# Altium schematic sheet DMP_SEM_POWER.SchDoc(DMP_SEM_POWER)
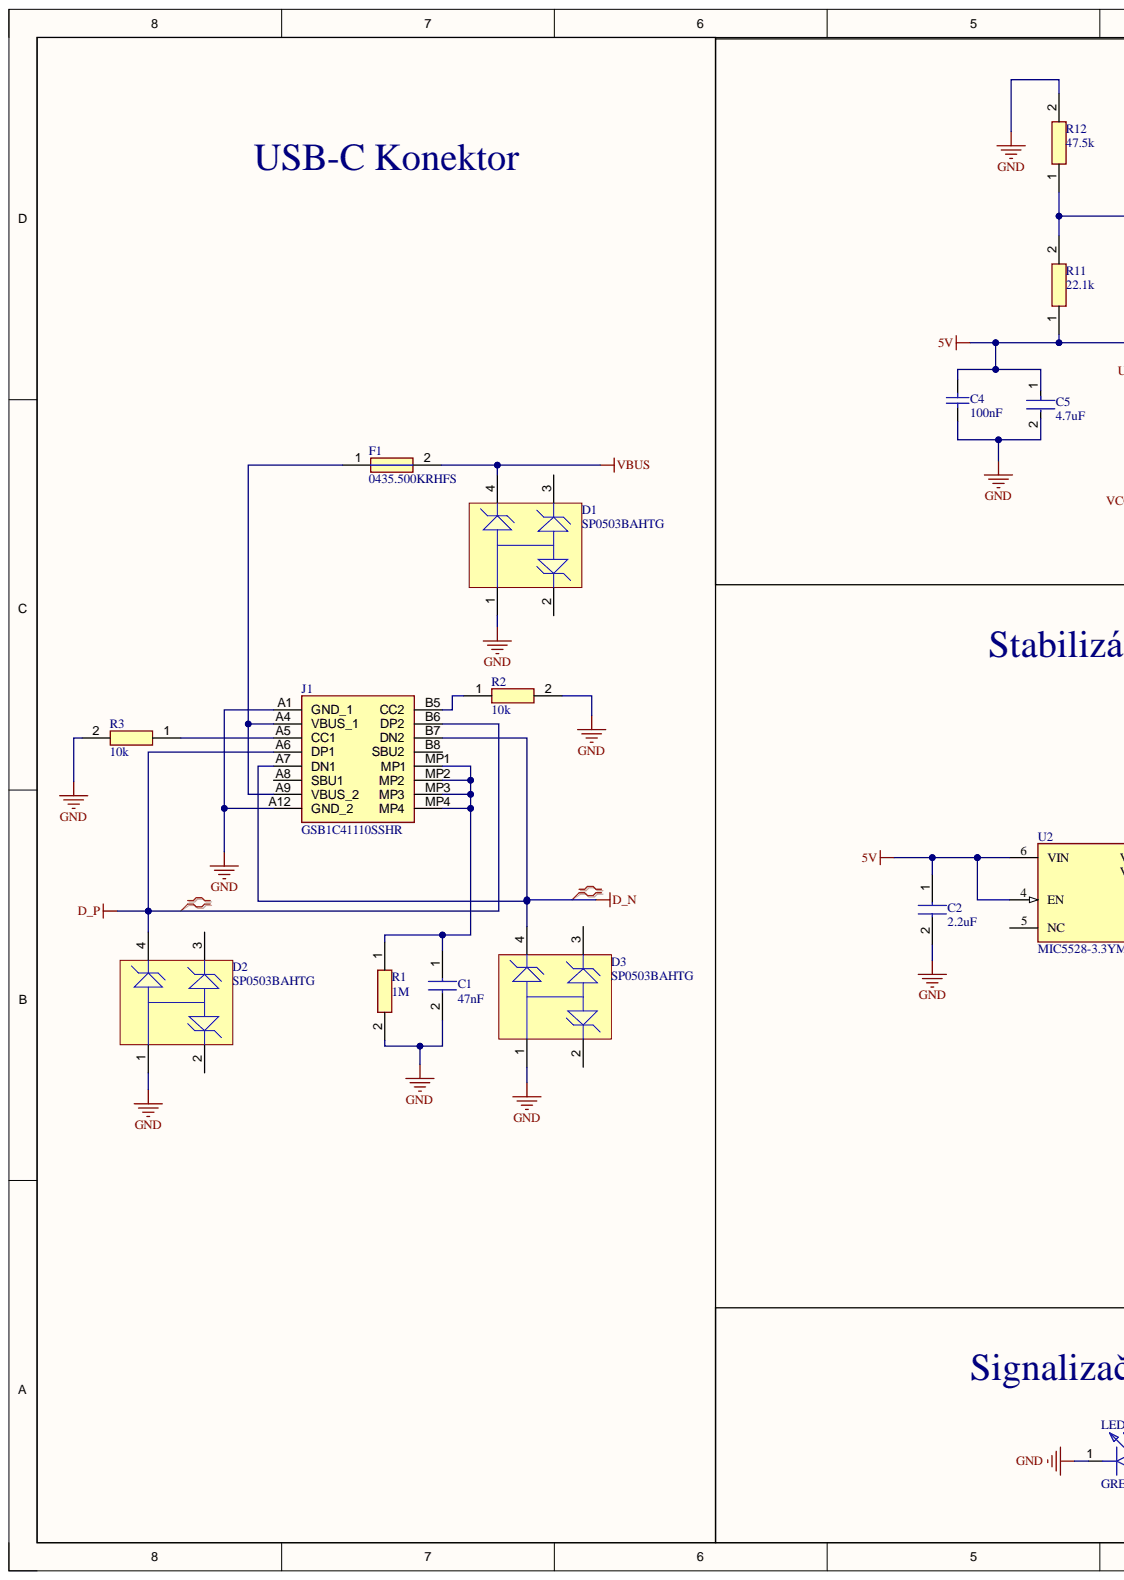
[diagram p0: DMP_SEM_POWER.SchDoc, left]
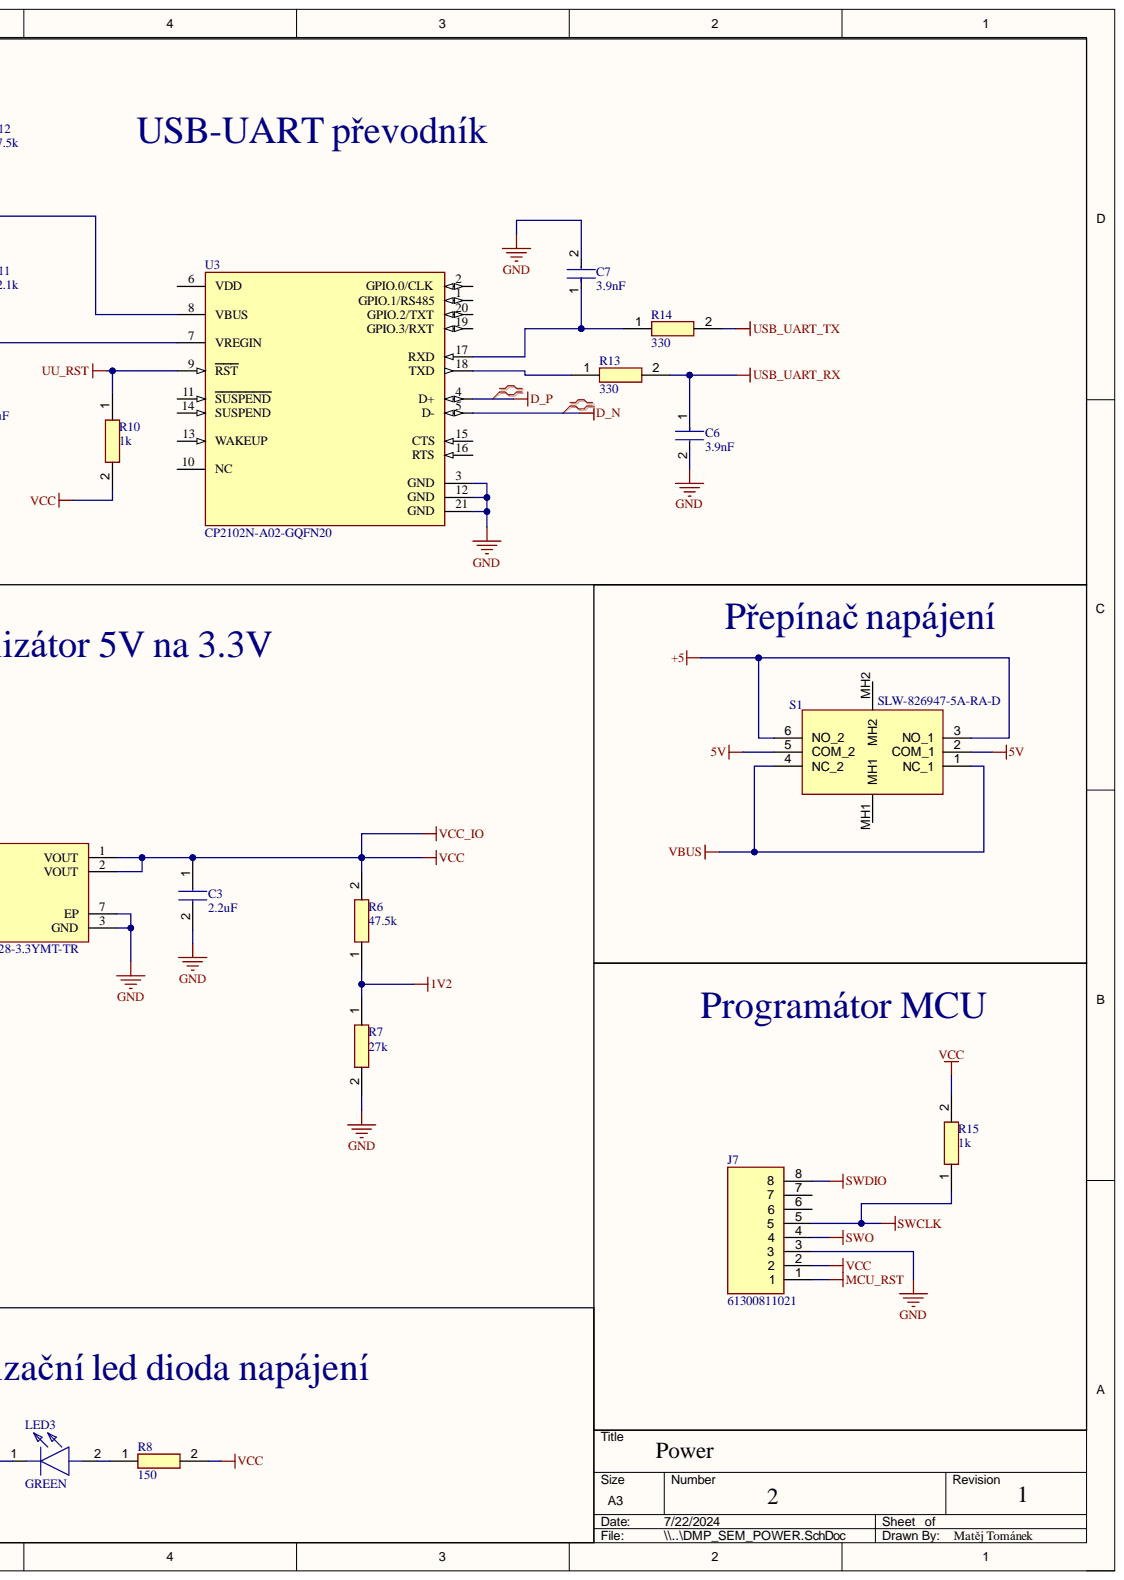
[diagram p1: DMP_SEM_POWER.SchDoc, right]

## Parts
C1  47nF  [C0603]
C2  2.2uF  [CAPC1608X90N]
C3  2.2uF  [CAPC1608X90N]
C4  100nF  [CAPC1050X55X23ML05T13]
C5  4.7uF  [FP-CL828-IPC_A]
C6  3.9nF  [FP-CL22-IPC_B]
C7  3.9nF  [FP-CL22-IPC_B]
D1  SP0503BAHTG  [LFUS-SOT143-4_V]
D2  SP0503BAHTG  [LFUS-SOT143-4_V]
D3  SP0503BAHTG  [LFUS-SOT143-4_V]
F1  0435.500KRHFS  [FUSC1005X43N]
J1  GSB1C41110SSHR  [GSB1C41110SSHR]
J7  61300811021  [HDRRA8W64P0X254_1X8_2032X254X254P]
LED3  GREEN  [LEDC1608X40N]
R1  1M  [RESC1005X40N]
R2  10k  [RESC1608X50N]
R3  10k  [RESC1608X50N]
R6  47.5k  [ERJP03_PA3_(0603)]
R7  27k  [ERA6AEB1020V]
R8  150  [RESC1005X40N]
R10  1k  [RESC1608X55N]
R11  22.1k  [RESC1608X55N]
R12  47.5k  [ERJP03_PA3_(0603)]
R13  330  [RESC1005X40N]
R14  330  [RESC1005X40N]
R15  1k  [RESC1608X55N]
S1  SLW-826947-5A-RA-D  [SLW8269475ARAD]
U2  MIC5528-3.3YMT-TR  [FP-TDFN1212-6LD-PL-1-IPC_A]
U3  CP2102N-A02-GQFN20  [FP-CP2102N-A02-GQFN20-MFG]

## Nets
1V2: R6.1 R7.1
5V: C2.1 C4.1 C5.1 R11.1 S1.2(COM_1) S1.3(NO_1) S1.5(COM_2) S1.6(NO_2) U2.4(EN) U2.6(VIN) U3.7(VREGIN)
D_N: D3.4 J1.A7(DN1) J1.B7(DN2) U3.5(D-)
D_P: D2.4 J1.A6(DP1) J1.B6(DP2) U3.4(D+)
GND: C1.2 C2.2 C3.2 C4.2 C5.2 C6.2 C7.2 D1.1 D2.1 D3.1 J1.A1(GND_1) J1.A12(GND_2) J7.3 LED3.1 R1.2 R2.2 R3.2 R7.2 R12.2 U2.3(GND) U2.7(EP) U3.3(GND) U3.12(GND) U3.21(GND)
MCU_RST: J7.1
SWCLK: J7.5 R15.1
SWDIO: J7.8
SWO: J7.4
USB_UART_RX: C6.1 R13.2
USB_UART_TX: R14.2
UU_RST: R10.1 U3.9(RST)
VBUS: D1.4 F1.2 S1.1(NC_1) S1.4(NC_2)
VCC: C3.1 J7.2 R6.2 R8.2 R10.2 R15.2 U2.1(VOUT) U2.2(VOUT)
NetC1_1: C1.1 J1.MP1 J1.MP2 J1.MP3 J1.MP4 R1.1
NetC7_1: C7.1 R14.1 U3.17(RXD)
NetF1_1: F1.1 J1.A4(VBUS_1) J1.A9(VBUS_2)
NetJ1_A5: J1.A5(CC1) R3.1
NetJ1_B5: J1.B5(CC2) R2.1
NetLED3_2: LED3.2 R8.1
NetR11_2: R11.2 R12.1 U3.8(VBUS)
NetR13_1: R13.1 U3.18(TXD)

Not connected: D1 2 3; D2 2 3; D3 2 3; J1 A8(SBU1) B8(SBU2); J7 6 7; S1 MH1 MH2; U2 5(NC); U3 1(GPIO.1/RS485) 2(GPIO.0/CLK) 6(VDD) 10(NC) 11(SUSPEND) 13(WAKEUP) 14(SUSPEND) 15(CTS) 16(RTS) 19(GPIO.3/RXT) 20(GPIO.2/TXT)

## Library data of the parts
C1 = C0603X473J3RAC3316: Capacitor C0603X473J3RAC3316; Manufacturer_Name: KEMET; Component Kind: Standard; Datasheet Link: https://content.kemet.com/datasheets/KEM_X7R_FT_VW_AUDI.pdf; Height: 0.87mm; Manufacturer_Part_Number: C0603X473J3RAC3316; Mouser Part Number: 80-C0603X473J3RC3316; Mouser Price/Stock: https://www.mouser.co.uk/ProductDetail/KEMET/C0603X473J3RAC3316?qs=u4fy%2FsgLU9PxJdvX5onriA%3D%3D
C2 C3 = GRM188R61C225KAADJ: Capacitor GRM188R61C225KAADJ; Manufacturer_Name: Murata Electronics; Component Kind: Standard; Datasheet Link: http://componentsearchengine.com/Datasheets/1/GRM188R61C225KAADJ.pdf; Height: 0.9mm; Manufacturer_Part_Number: GRM188R61C225KAADJ; Mouser Part Number: 81-GRM188R61C225KADJ; Mouser Price/Stock: https://www.mouser.co.uk/ProductDetail/Murata-Electronics/GRM188R61C225KAADJ?qs=QzBtWTOodeWhnkdg0se%2F2g%3D%3D
C4 = CMP-2000-05439-1: Chip Capacitor, 100 nF, +/- 10%, 16 V, -55 to 125 degC, 0402 (1005 Metric), RoHS, Tape and ReelCL05B104KO5NNNC; Manufacturer: Samsung Electro-Mechanics; Capacitance: 100000pF; Dielectric: X7R; Rated Voltage: 16V; Case Size/Dimension: 0402; Component Kind: Standard; Datasheet URL: https://datasheet.ciiva.com/29198/458718-da-01-en-vs-kondensator-0402-29198423.pdf; Datasheet Version: Nov-10; Library Name: Altium Content Vault; Manufacturer URL: http://www.samsungsem.com/global/index.jsp; Tolerance (%): ±10%
C5 = CMP-13271-001251-1: Cap Ceramic 4.7uF 10V X5R ±10% SMD 0402 +85°C Paper T/R   CL05A475KP5NRNC; Capacitance: 4.7uF; Case Code (Imperial): 0402; Case Code (Metric): 1005; Case/Package: 0402; Component Kind: Standard; Depth: 0.5mm; Dielectric: X5R; Height: 0.5mm; Lead Free: Lead Free; Length: 1mm; Library Name: Altium Content Vault; Material: Ceramic; Max Operating Temperature: 85°C; Min Operating Temperature: -55°C; Mount: Surface Mount; Package Quantity: 1; Packaging: Tape and Reel; Radiation Hardening: No; Thickness: 0.022inch; Tolerance: 10%; Voltage Rating: 10V; Width: 0.02inch
C6 C7 = CMP-13271-003872-1: Cap Ceramic 3.9nF 50V X7R ±10% SMD 0402 +125°C Paper T/R     CL05B392KB5NNNC; Capacitance: 3.9nF; Case Code (Imperial): 0402; Case Code (Metric): 1005; Case/Package: 0402; Component Kind: Standard; Contact Plating: Tin; Depth: 0.5mm; Dielectric: X7R; Height: 0.5mm; Lead Free: Lead Free; Length: 1mm; Library Name: Altium Content Vault; Material: Ceramic; Max Operating Temperature: 125°C; Min Operating Temperature: -55°C; Mount: Surface Mount; Package Quantity: 1; Packaging: Tape and Reel; Radiation Hardening: No; Thickness: 0.022inch; Tolerance: 10%; Voltage: 50V; Voltage Rating: 50V; Voltage Rating (DC): 50V; Width: 0.02inch
D1-D3 = CMP-2000-05191-1: TVS Diode Array, 30 pF, 30 KV, -40 to 85 degC, 4-Pin SOT143, RoHS, Tape and Reel; Manufacturer: Littelfuse; Capacitance Value: 30pF; Clamping Voltage: 8V; Configuration: Triple; Direction Type: Uni-Directional; Leakage Current: 0.1uA; Number of Elements: 3; Product Depth (mm): 1.4mm; Product Height (mm): 1.05mm; Product Length (mm): 3.04mm; Rad Hardened: No; Reverse Stand-off Voltage: 5.5(Min)V; Working Voltage: 5.5V; Working Voltage (Max): 5.5V(Min); Clamping Voltage (Max): 8V; Component Kind: Standard; Datasheet URL: https://datasheet.ciiva.com/15143/getdatasheetpartid-116020-15143550.pdf; Datasheet Version: Dec-13; Leakage Current (Max): 0.1uA; Library Name: Altium Content Vault; Manufacturer URL: http://www.littelfuse.com/; Mounting: SurfaceMount; Mounting Style: SurfaceMount; Operating Temp Range: -40Cto85C; Operating Temperature Classification: Industrial; Operating Temperature Max Deg. C: 85C; Operating Temperature Min Deg. C: -40C; Package / Case: SOT-143; Package Type: SOT-143; Packaging: TapeandReel; Pin Count: 3+Tab; Polarity: Uni-Directional; Suppressor Type: TVS
F1 = 0435.500KRHFS: Fuse; Manufacturer_Name: LITTELFUSE; Component Kind: Standard; Datasheet Link: https://4donline.ihs.com/images/VipMasterIC/IC/LFSI/LFSI-S-A0001437699/LFSI-S-A0001437699-1.pdf?hkey=EF798316E3902B6ED9A73243A3159BB0; Height: 0.432mm; Library Name: SamacSys.SchLib; Manufacturer_Part_Number: 0435.500KRHFS
J1 = GSB1C41110SSHR: Connector; Manufacturer_Name: Amphenol Communications Solutions; Component Kind: Standard; Datasheet Link: https://cdn.amphenol-cs.com/media/wysiwyg/files/drawing/gsb1c41x10sshr-ax1.pdf; Height: 3.41mm; Manufacturer_Part_Number: GSB1C41110SSHR
J7 = 61300811021: Connector (programing connector); Manufacturer_Name: Wurth Elektronik; Component Kind: Standard; ComponentLink1Description: shop; ComponentLink1URL: https://cz.mouser.com/ProductDetail/Wurth-Elektronik/61300811021?qs=PhR8RmCirEbjOoHsfjdbkQ%3D%3D; Datasheet Link: http://katalog.we-online.de/em/datasheet/6130xx11021.pdf; Height: 2.54mm; Manufacturer_Part_Number: 61300811021; Mouser Part Number: 710-61300811021; Mouser Price/Stock: https://www.mouser.co.uk/ProductDetail/Wurth-Elektronik/61300811021?qs=PhR8RmCirEbjOoHsfjdbkQ%3D%3D
LED3 = SMLEN3EC8TT86: LED GREEN SMLEN3EC8TT86; Manufacturer_Name: ROHM Semiconductor; Component Kind: Standard; ComponentLink1Description: shop; ComponentLink1URL: https://cz.mouser.com/ProductDetail/ROHM-Semiconductor/SMLEN3EC8TT86?qs=Znm5pLBrcALM%2FhD2B8BI%2FQ%3D%3D; Datasheet Link: https://fscdn.rohm.com/en/products/databook/datasheet/opto/led/chip_mono/smlen3ec8tt86-e.pdf; Height: 0.4mm; Manufacturer_Part_Number: SMLEN3EC8TT86; Mouser Part Number: 755-SMLEN3EC8TT86; Mouser Price/Stock: https://www.mouser.co.uk/ProductDetail/ROHM-Semiconductor/SMLEN3EC8TT86?qs=Znm5pLBrcALM%2FhD2B8BI%2FQ%3D%3D
R1 = RC0402FR-071ML: Resistor RC0402FR-071ML; Manufacturer_Name: KEMET; Component Kind: Standard; ComponentLink1Description: shop; ComponentLink1URL: https://cz.mouser.com/ProductDetail/YAGEO/RC0402FR-071ML?qs=V1yeUXFNrknfoI4987wfBQ%3D%3D; Datasheet Link: http://www.yageo.com/documents/recent/PYu-RC0402_51_RoHS_L_6_r.pdf; Height: 0.4mm; Library Name: SamacSys.SchLib; Manufacturer_Part_Number: RC0402FR-071ML; Mouser Part Number: 603-RC0402FR-071ML; Mouser Price/Stock: https://www.mouser.co.uk/ProductDetail/YAGEO/RC0402FR-071ML?qs=V1yeUXFNrknfoI4987wfBQ%3D%3D
R2 R3 = CRCW060310K0FKEA: Resistor CRCW060310K0FKEA; Manufacturer_Name: Vishay; Arrow Part Number: CRCW060310K0FKEA; Arrow Price/Stock: https://www.arrow.com/en/products/crcw060310k0fkea/vishay?region=nac; Component Kind: Standard; ComponentLink1Description: shop; ComponentLink1URL: https://cz.mouser.com/ProductDetail/Vishay-Dale/CRCW060310K0FKEA?qs=pAO1Z172M84BVnhDjtnsoQ%3D%3D; Datasheet Link: http://www.vishay.com/docs/20035/dcrcwe3.pdf; Height: 0.5mm; Manufacturer_Part_Number: CRCW060310K0FKEA; Mouser Part Number: 71-CRCW0603-10K-E3; Mouser Price/Stock: https://www.mouser.co.uk/ProductDetail/Vishay-Dale/CRCW060310K0FKEA?qs=pAO1Z172M84BVnhDjtnsoQ%3D%3D
R6 R12 = ERJ-PA3D4752V: Resistor ERJ-PA3D4752V; Manufacturer_Name: Panasonic; Component Kind: Standard; ComponentLink1Description: shop; ComponentLink1URL: https://cz.mouser.com/ProductDetail/Panasonic/ERJ-PA3D4752V?qs=sGAEpiMZZMvdGkrng054t6%2FAfIpq60YDheWhyQd1h%252BlH%252BmzCFBvaFw%3D%3D; Datasheet Link: https://industrial.panasonic.com/cdbs/www-data/pdf/RDO0000/AOA0000C331.pdf; Height: 0.55mm; Manufacturer_Part_Number: ERJ-PA3D4752V; Mouser Part Number: 667-ERJ-PA3D4752V; Mouser Price/Stock: https://www.mouser.co.uk/ProductDetail/Panasonic/ERJ-PA3D4752V?qs=BzJM0faLVqWBfdYKa9t4jw%3D%3D
R7 = ERA-6AED273V: Resistor ERA-6AED273V; Manufacturer_Name: Panasonic; Component Kind: Standard; Datasheet Link: https://industrial.panasonic.com/cdbs/www-data/pdf/RDM0000/AOA0000C307.pdf; Height: 0.6mm; Manufacturer_Part_Number: ERA-6AED273V; Mouser Part Number: 667-ERA-6AED273V; Mouser Price/Stock: https://www.mouser.com/Search/Refine.aspx?Keyword=667-ERA-6AED273V
R8 = CRCW0402150RFKEDHP: Resistor CRCW0402150RFKEDHP; Manufacturer_Name: Vishay; Arrow Part Number: CRCW0402150RFKEDHP; Arrow Price/Stock: https://www.arrow.com/en/products/crcw0402150rfkedhp/vishay?region=nac; Component Kind: Standard; ComponentLink1Description: shop; ComponentLink1URL: https://cz.mouser.com/ProductDetail/Vishay-Dale/CRCW0402150RFKEDHP?qs=40TFAHZMFvfqtIOyP%252B2vGQ%3D%3D; Datasheet Link: http://www.vishay.com/docs/20035/dcrcwe3.pdf; Height: 0.4mm; Manufacturer_Part_Number: CRCW0402150RFKEDHP; Mouser Part Number: 71-CRCW0402150RFKEDH; Mouser Price/Stock: https://www.mouser.co.uk/ProductDetail/Vishay-Dale/CRCW0402150RFKEDHP?qs=40TFAHZMFvfqtIOyP%252B2vGQ%3D%3D
R10 R15 = RC0603FR-071KL: Resistor RC0603FR-071KL; Manufacturer_Name: YAGEO; Arrow Part Number: RC0603FR-071KL; Arrow Price/Stock: https://www.arrow.com/en/products/rc0603fr-071kl/yageo?region=europe; Component Kind: Standard; ComponentLink1Description: shop; ComponentLink1URL: https://cz.mouser.com/ProductDetail/YAGEO/RC0603FR-071KL?qs=VU8sRB4EgwApHsk4rF%2F3zg%3D%3D; Datasheet Link: https://www.yageo.com/upload/media/product/productsearch/datasheet/rchip/PYu-RC_Group_51_RoHS_L_12.pdf; Height: 0.55mm; Library Name: SamacSys.SchLib; Manufacturer_Part_Number: RC0603FR-071KL; Mouser Part Number: 603-RC0603FR-071KL; Mouser Price/Stock: https://www.mouser.co.uk/ProductDetail/YAGEO/RC0603FR-071KL?qs=VU8sRB4EgwApHsk4rF%2F3zg%3D%3D
R11 = ERJ3RBD2212V: Resistor ERJ3RBD2212V; Manufacturer_Name: Panasonic; Component Kind: Standard; ComponentLink1Description: shop; ComponentLink1URL: https://cz.mouser.com/ProductDetail/Panasonic/ERJ-3RBD2212V?qs=sGAEpiMZZMvdGkrng054t112T3y8TVsS6EjUUMA0whc%3D; Datasheet Link: http://docs-europe.electrocomponents.com/webdocs/13ba/0900766b813ba21b.pdf; Height: 0.55mm; Manufacturer_Part_Number: ERJ3RBD2212V
R13 R14 = RC0402FR-07330RL: Resistor RC0402FR-07330RL; Manufacturer_Name: KEMET; Arrow Part Number: RC0402FR-07330RL; Arrow Price/Stock: https://www.arrow.com/en/products/rc0402fr-07330rl/yageo; Component Kind: Standard; ComponentLink1Description: shop; ComponentLink1URL: https://cz.mouser.com/ProductDetail/YAGEO/RC0402FR-07330RL?qs=V1yeUXFNrkngRWHRQxns%2Fg%3D%3D; Datasheet Link: http://www.yageo.com/documents/recent/PYu-RC0402_51_RoHS_L_6_r.pdf; Height: 0.4mm; Library Name: SamacSys.SchLib; Manufacturer_Part_Number: RC0402FR-07330RL; Mouser Part Number: 603-RC0402FR-07330RL; Mouser Price/Stock: https://www.mouser.co.uk/ProductDetail/Yageo/RC0402FR-07330RL?qs=V1yeUXFNrkngRWHRQxns%2Fg%3D%3D
S1 = SLW-826947-5A-RA-D: Switch; Manufacturer_Name: CUI Devices; Arrow Part Number: SLW-826947-5A-RA-D; Arrow Price/Stock: https://www.arrow.com/en/products/slw-826947-5a-ra-d/cui-devices?region=nac; Component Kind: Standard; ComponentLink1Description: shop; ComponentLink1URL: https://cz.mouser.com/ProductDetail/CUI-Devices/SLW-826947-5A-RA-D?qs=1Kr7Jg1SGW8v9IaaOZIpLQ%3D%3D; Datasheet Link: https://www.cuidevices.com/product/resource/slw-826947-5a-ra-d.pdf; Height: 4.9mm; Manufacturer_Part_Number: SLW-826947-5A-RA-D; Mouser Part Number: 179-SLW-826947-5ARAD; Mouser Price/Stock: https://www.mouser.co.uk/ProductDetail/CUI-Devices/SLW-826947-5A-RA-D?qs=1Kr7Jg1SGW8v9IaaOZIpLQ%3D%3D
U2 = CMP-06324-000078-1: IC REG LINEAR 3.3V 500MA 6TDFN; Dropout Voltage: 260mV; Min Current Limit: 525mA; Number of Regulators: 1; Component Kind: Standard; Library Name: Altium Content Vault; Max Operating Temperature: 125°C; Min Operating Temperature: -40°C; Mount: Surface Mount; Output Current: 500mA; Output Voltage: 3.3V; Packaging: Tape and Reel
U3 = CMP-08093-000006-1: IC USB TO UART BRIDGE QFN20; Component Kind: Standard; Library Name: Altium Content Vault
